# Altium schematic sheet 8DMD5x7 v3.Sch("8DMD5x7 v3")
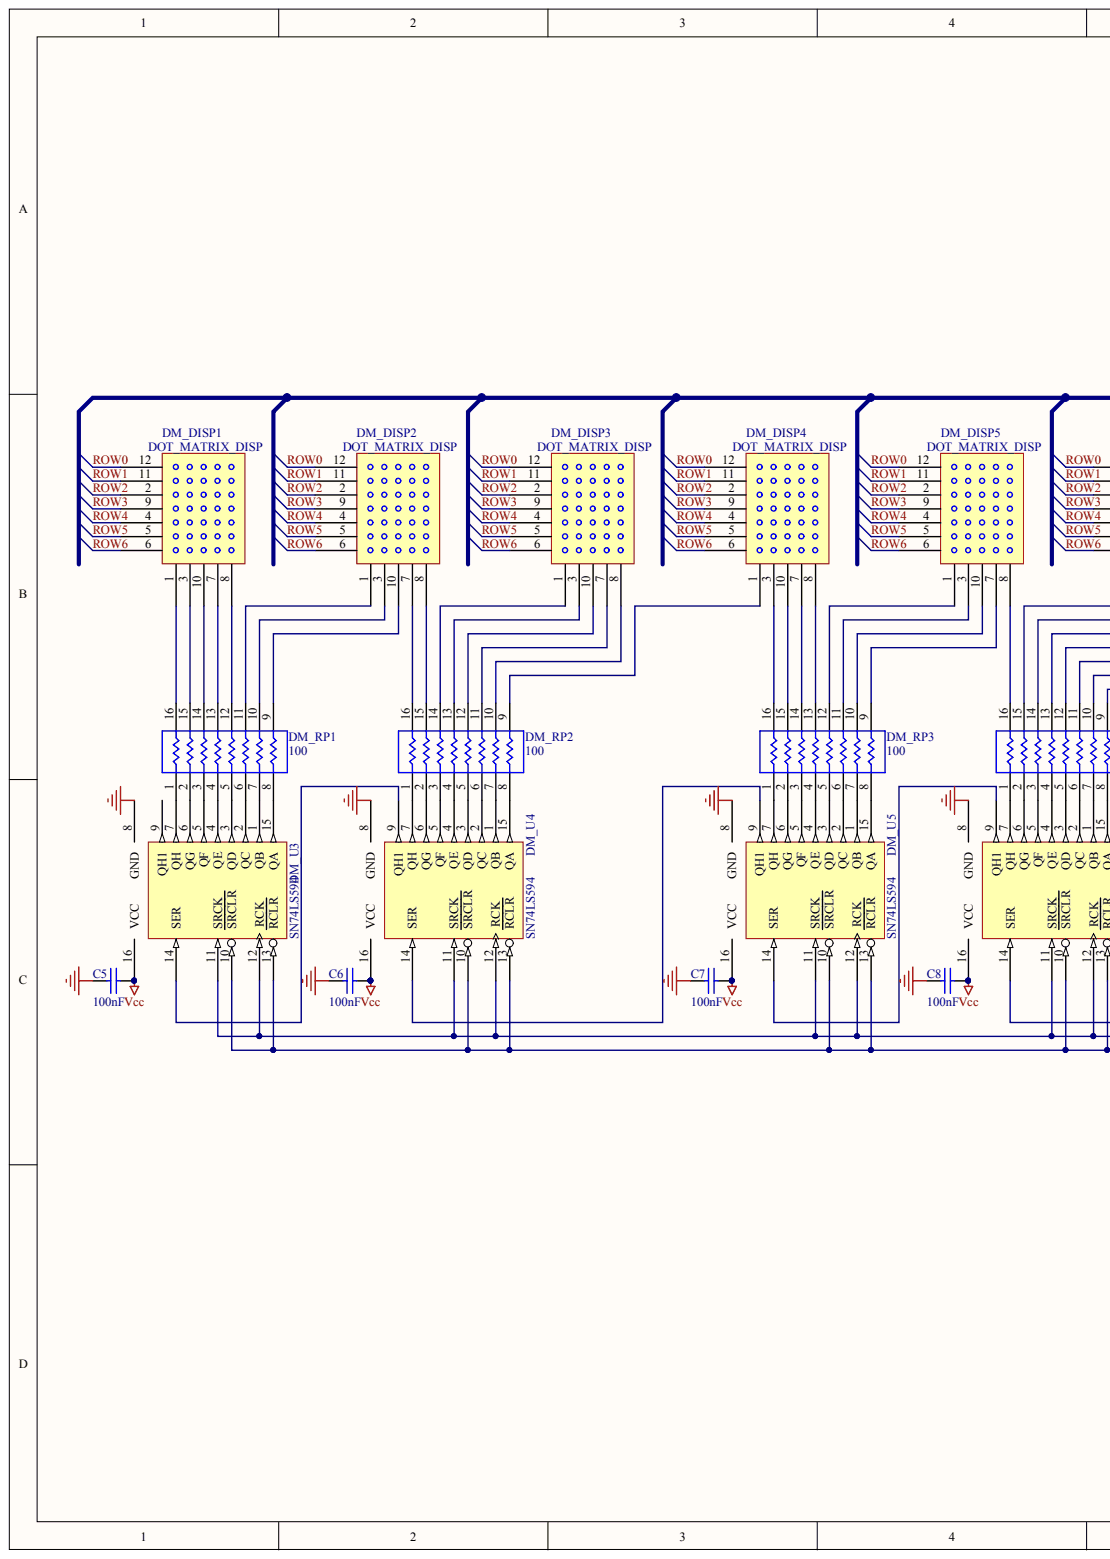
[diagram p0: 8DMD5x7 v3.Sch("8DMD5x7 v3"), left]
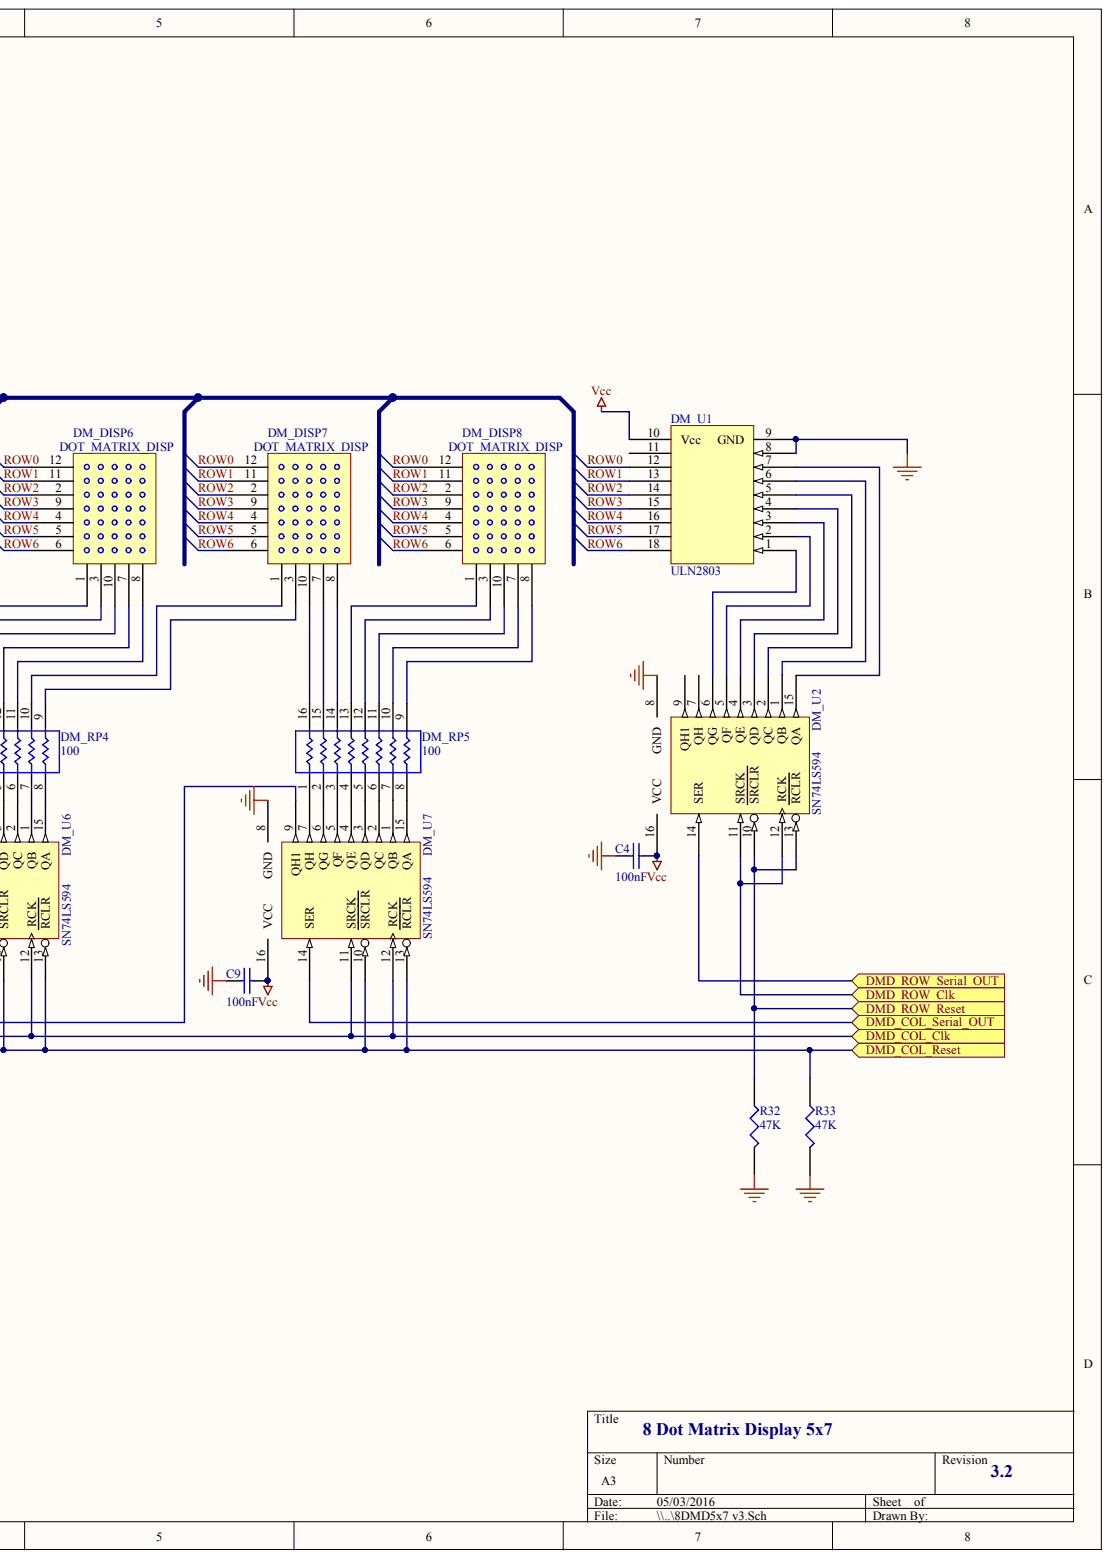
[diagram p1: 8DMD5x7 v3.Sch("8DMD5x7 v3"), right]

## Parts
C4  100nF  [J1-0603]
C5  100nF  [J1-0603]
C6  100nF  [J1-0603]
C7  100nF  [J1-0603]
C8  100nF  [J1-0603]
C9  100nF  [J1-0603]
DM_DISP1  DOT_MATRIX_DISP  [DOT_MATRIX_5X7]
DM_DISP2  DOT_MATRIX_DISP  [DOT_MATRIX_5X7]
DM_DISP3  DOT_MATRIX_DISP  [DOT_MATRIX_5X7]
DM_DISP4  DOT_MATRIX_DISP  [DOT_MATRIX_5X7]
DM_DISP5  DOT_MATRIX_DISP  [DOT_MATRIX_5X7]
DM_DISP6  DOT_MATRIX_DISP  [DOT_MATRIX_5X7]
DM_DISP7  DOT_MATRIX_DISP  [DOT_MATRIX_5X7]
DM_DISP8  DOT_MATRIX_DISP  [DOT_MATRIX_5X7]
DM_RP1  100  [DIP16]
DM_RP2  100  [DIP16]
DM_RP3  100  [DIP16]
DM_RP4  100  [DIP16]
DM_RP5  100  [DIP16]
DM_U1  ULN2803  [DIP18]
DM_U2  SN74LS594  [DIP16]
DM_U3  SN74LS594  [DIP16]
DM_U4  SN74LS594  [DIP16]
DM_U5  SN74LS594  [DIP16]
DM_U6  SN74LS594  [DIP16]
DM_U7  SN74LS594  [DIP16]
R32  47K  [J1-0603]
R33  47K  [J1-0603]

## Ports
DMD_COL_CLK, DMD_COL_RESET, DMD_COL_SERIAL_OUT, DMD_ROW_CLK, DMD_ROW_RESET, DMD_ROW_SERIAL_OUT

## Nets
GND: C4.2 C5.2 C6.2 C7.2 C8.2 C9.2 DM_U1.8 DM_U1.9(GND) DM_U2.8(GND) DM_U3.8(GND) DM_U4.8(GND) DM_U5.8(GND) DM_U6.8(GND) DM_U7.8(GND) R32.2 R33.2
VCC: C4.1 C5.1 C6.1 C7.1 C8.1 C9.1 DM_U1.10(Vcc) DM_U2.16(VCC) DM_U3.16(VCC) DM_U4.16(VCC) DM_U5.16(VCC) DM_U6.16(VCC) DM_U7.16(VCC)
ROW0: DM_DISP1.12 DM_DISP2.12 DM_DISP3.12 DM_DISP4.12 DM_DISP5.12 DM_DISP6.12 DM_DISP7.12 DM_DISP8.12 DM_U1.12
ROW1: DM_DISP1.11 DM_DISP2.11 DM_DISP3.11 DM_DISP4.11 DM_DISP5.11 DM_DISP6.11 DM_DISP7.11 DM_DISP8.11 DM_U1.13
ROW2: DM_DISP1.2 DM_DISP2.2 DM_DISP3.2 DM_DISP4.2 DM_DISP5.2 DM_DISP6.2 DM_DISP7.2 DM_DISP8.2 DM_U1.14
ROW3: DM_DISP1.9 DM_DISP2.9 DM_DISP3.9 DM_DISP4.9 DM_DISP5.9 DM_DISP6.9 DM_DISP7.9 DM_DISP8.9 DM_U1.15
ROW4: DM_DISP1.4 DM_DISP2.4 DM_DISP3.4 DM_DISP4.4 DM_DISP5.4 DM_DISP6.4 DM_DISP7.4 DM_DISP8.4 DM_U1.16
ROW5: DM_DISP1.5 DM_DISP2.5 DM_DISP3.5 DM_DISP4.5 DM_DISP5.5 DM_DISP6.5 DM_DISP7.5 DM_DISP8.5 DM_U1.17
ROW6: DM_DISP1.6 DM_DISP2.6 DM_DISP3.6 DM_DISP4.6 DM_DISP5.6 DM_DISP6.6 DM_DISP7.6 DM_DISP8.6 DM_U1.18
NetDM_DISP1_1: DM_DISP1.1 DM_RP1.16
NetDM_DISP1_3: DM_DISP1.3 DM_RP1.15
NetDM_DISP1_7: DM_DISP1.7 DM_RP1.13
NetDM_DISP1_8: DM_DISP1.8 DM_RP1.12
NetDM_DISP1_10: DM_DISP1.10 DM_RP1.14
NetDM_DISP2_1: DM_DISP2.1 DM_RP1.11
NetDM_DISP2_3: DM_DISP2.3 DM_RP1.10
NetDM_DISP2_7: DM_DISP2.7 DM_RP2.16
NetDM_DISP2_8: DM_DISP2.8 DM_RP2.15
NetDM_DISP2_10: DM_DISP2.10 DM_RP1.9
NetDM_DISP3_1: DM_DISP3.1 DM_RP2.14
NetDM_DISP3_3: DM_DISP3.3 DM_RP2.13
NetDM_DISP3_7: DM_DISP3.7 DM_RP2.11
NetDM_DISP3_8: DM_DISP3.8 DM_RP2.10
NetDM_DISP3_10: DM_DISP3.10 DM_RP2.12
NetDM_DISP4_1: DM_DISP4.1 DM_RP2.9
NetDM_DISP4_3: DM_DISP4.3 DM_RP3.16
NetDM_DISP4_7: DM_DISP4.7 DM_RP3.14
NetDM_DISP4_8: DM_DISP4.8 DM_RP3.13
NetDM_DISP4_10: DM_DISP4.10 DM_RP3.15
NetDM_DISP5_1: DM_DISP5.1 DM_RP3.12
NetDM_DISP5_3: DM_DISP5.3 DM_RP3.11
NetDM_DISP5_7: DM_DISP5.7 DM_RP3.9
NetDM_DISP5_8: DM_DISP5.8 DM_RP4.16
NetDM_DISP5_10: DM_DISP5.10 DM_RP3.10
NetDM_DISP6_1: DM_DISP6.1 DM_RP4.15
NetDM_DISP6_3: DM_DISP6.3 DM_RP4.14
NetDM_DISP6_7: DM_DISP6.7 DM_RP4.12
NetDM_DISP6_8: DM_DISP6.8 DM_RP4.11
NetDM_DISP6_10: DM_DISP6.10 DM_RP4.13
NetDM_DISP7_1: DM_DISP7.1 DM_RP4.10
NetDM_DISP7_3: DM_DISP7.3 DM_RP4.9
NetDM_DISP7_7: DM_DISP7.7 DM_RP5.15
NetDM_DISP7_8: DM_DISP7.8 DM_RP5.14
NetDM_DISP7_10: DM_DISP7.10 DM_RP5.16
NetDM_DISP8_1: DM_DISP8.1 DM_RP5.13
NetDM_DISP8_3: DM_DISP8.3 DM_RP5.12
NetDM_DISP8_7: DM_DISP8.7 DM_RP5.10
NetDM_DISP8_8: DM_DISP8.8 DM_RP5.9
NetDM_DISP8_10: DM_DISP8.10 DM_RP5.11
NetDM_RP1_1: DM_RP1.1 DM_U3.7(QH)
NetDM_RP1_2: DM_RP1.2 DM_U3.6(QG)
NetDM_RP1_3: DM_RP1.3 DM_U3.5(QF)
NetDM_RP1_4: DM_RP1.4 DM_U3.4(QE)
NetDM_RP1_5: DM_RP1.5 DM_U3.3(QD)
NetDM_RP1_6: DM_RP1.6 DM_U3.2(QC)
NetDM_RP1_7: DM_RP1.7 DM_U3.1(QB)
NetDM_RP1_8: DM_RP1.8 DM_U3.15(QA)
NetDM_RP2_1: DM_RP2.1 DM_U4.7(QH)
NetDM_RP2_2: DM_RP2.2 DM_U4.6(QG)
NetDM_RP2_3: DM_RP2.3 DM_U4.5(QF)
NetDM_RP2_4: DM_RP2.4 DM_U4.4(QE)
NetDM_RP2_5: DM_RP2.5 DM_U4.3(QD)
NetDM_RP2_6: DM_RP2.6 DM_U4.2(QC)
NetDM_RP2_7: DM_RP2.7 DM_U4.1(QB)
NetDM_RP2_8: DM_RP2.8 DM_U4.15(QA)
NetDM_RP3_1: DM_RP3.1 DM_U5.7(QH)
NetDM_RP3_2: DM_RP3.2 DM_U5.6(QG)
NetDM_RP3_3: DM_RP3.3 DM_U5.5(QF)
NetDM_RP3_4: DM_RP3.4 DM_U5.4(QE)
NetDM_RP3_5: DM_RP3.5 DM_U5.3(QD)
NetDM_RP3_6: DM_RP3.6 DM_U5.2(QC)
NetDM_RP3_7: DM_RP3.7 DM_U5.1(QB)
NetDM_RP3_8: DM_RP3.8 DM_U5.15(QA)
NetDM_RP4_1: DM_RP4.1 DM_U6.7(QH)
NetDM_RP4_2: DM_RP4.2 DM_U6.6(QG)
NetDM_RP4_3: DM_RP4.3 DM_U6.5(QF)
NetDM_RP4_4: DM_RP4.4 DM_U6.4(QE)
NetDM_RP4_5: DM_RP4.5 DM_U6.3(QD)
NetDM_RP4_6: DM_RP4.6 DM_U6.2(QC)
NetDM_RP4_7: DM_RP4.7 DM_U6.1(QB)
NetDM_RP4_8: DM_RP4.8 DM_U6.15(QA)
NetDM_RP5_1: DM_RP5.1 DM_U7.7(QH)
NetDM_RP5_2: DM_RP5.2 DM_U7.6(QG)
NetDM_RP5_3: DM_RP5.3 DM_U7.5(QF)
NetDM_RP5_4: DM_RP5.4 DM_U7.4(QE)
NetDM_RP5_5: DM_RP5.5 DM_U7.3(QD)
NetDM_RP5_6: DM_RP5.6 DM_U7.2(QC)
NetDM_RP5_7: DM_RP5.7 DM_U7.1(QB)
NetDM_RP5_8: DM_RP5.8 DM_U7.15(QA)
NetDM_U1_1: DM_U1.1 DM_U2.6(QG)
NetDM_U1_2: DM_U1.2 DM_U2.5(QF)
NetDM_U1_3: DM_U1.3 DM_U2.4(QE)
NetDM_U1_4: DM_U1.4 DM_U2.3(QD)
NetDM_U1_5: DM_U1.5 DM_U2.2(QC)
NetDM_U1_6: DM_U1.6 DM_U2.1(QB)
NetDM_U1_7: DM_U1.7 DM_U2.15(QA)
NetDM_U2_10: DM_U2.10(SRCLR) DM_U2.13(RCLR) R32.1 ; port DMD_ROW_RESET
NetDM_U2_11: DM_U2.11(SRCK) DM_U2.12(RCK) ; port DMD_ROW_CLK
NetDM_U2_14: DM_U2.14(SER) ; port DMD_ROW_SERIAL_OUT
NetDM_U3_10: DM_U3.10(SRCLR) DM_U3.13(RCLR) DM_U4.10(SRCLR) DM_U4.13(RCLR) DM_U5.10(SRCLR) DM_U5.13(RCLR) DM_U6.10(SRCLR) DM_U6.13(RCLR) DM_U7.10(SRCLR) DM_U7.13(RCLR) R33.1 ; port DMD_COL_RESET
NetDM_U3_11: DM_U3.11(SRCK) DM_U3.12(RCK) DM_U4.11(SRCK) DM_U4.12(RCK) DM_U5.11(SRCK) DM_U5.12(RCK) DM_U6.11(SRCK) DM_U6.12(RCK) DM_U7.11(SRCK) DM_U7.12(RCK) ; port DMD_COL_CLK
NetDM_U3_14: DM_U3.14(SER) DM_U4.9(QH1)
NetDM_U4_14: DM_U4.14(SER) DM_U5.9(QH1)
NetDM_U5_14: DM_U5.14(SER) DM_U6.9(QH1)
NetDM_U6_14: DM_U6.14(SER) DM_U7.9(QH1)
NetDM_U7_14: DM_U7.14(SER) ; port DMD_COL_SERIAL_OUT
N00002:

Not connected: DM_U1 11; DM_U2 7(QH) 9(QH1); DM_U3 9(QH1)

## Library data of the parts
C4-C9 = CAP: Capacitor; Component Kind: Standard
DM_DISP1-DM_DISP8 = DOT_MATRIX_DISP: Dot Matrix Display 5x7; Component Kind: Standard; Library Name: FPGA IO Extension.SCHLIB
DM_RP1-DM_RP5 = RESPACK3: Component Kind: Standard
DM_U1 = ULN2803: Octal High Voltage, High Current Darlington Transistor Arrays; Component Kind: Standard
DM_U2-DM_U7 = SN74LS594: 8-BIT SFT REG WIRTH OUTPUT LCH; Component Kind: Standard
R32 R33 = RES1: Component Kind: Standard
